# Altium schematic sheet MiniTVV4.SchDoc(MiniTVV4)
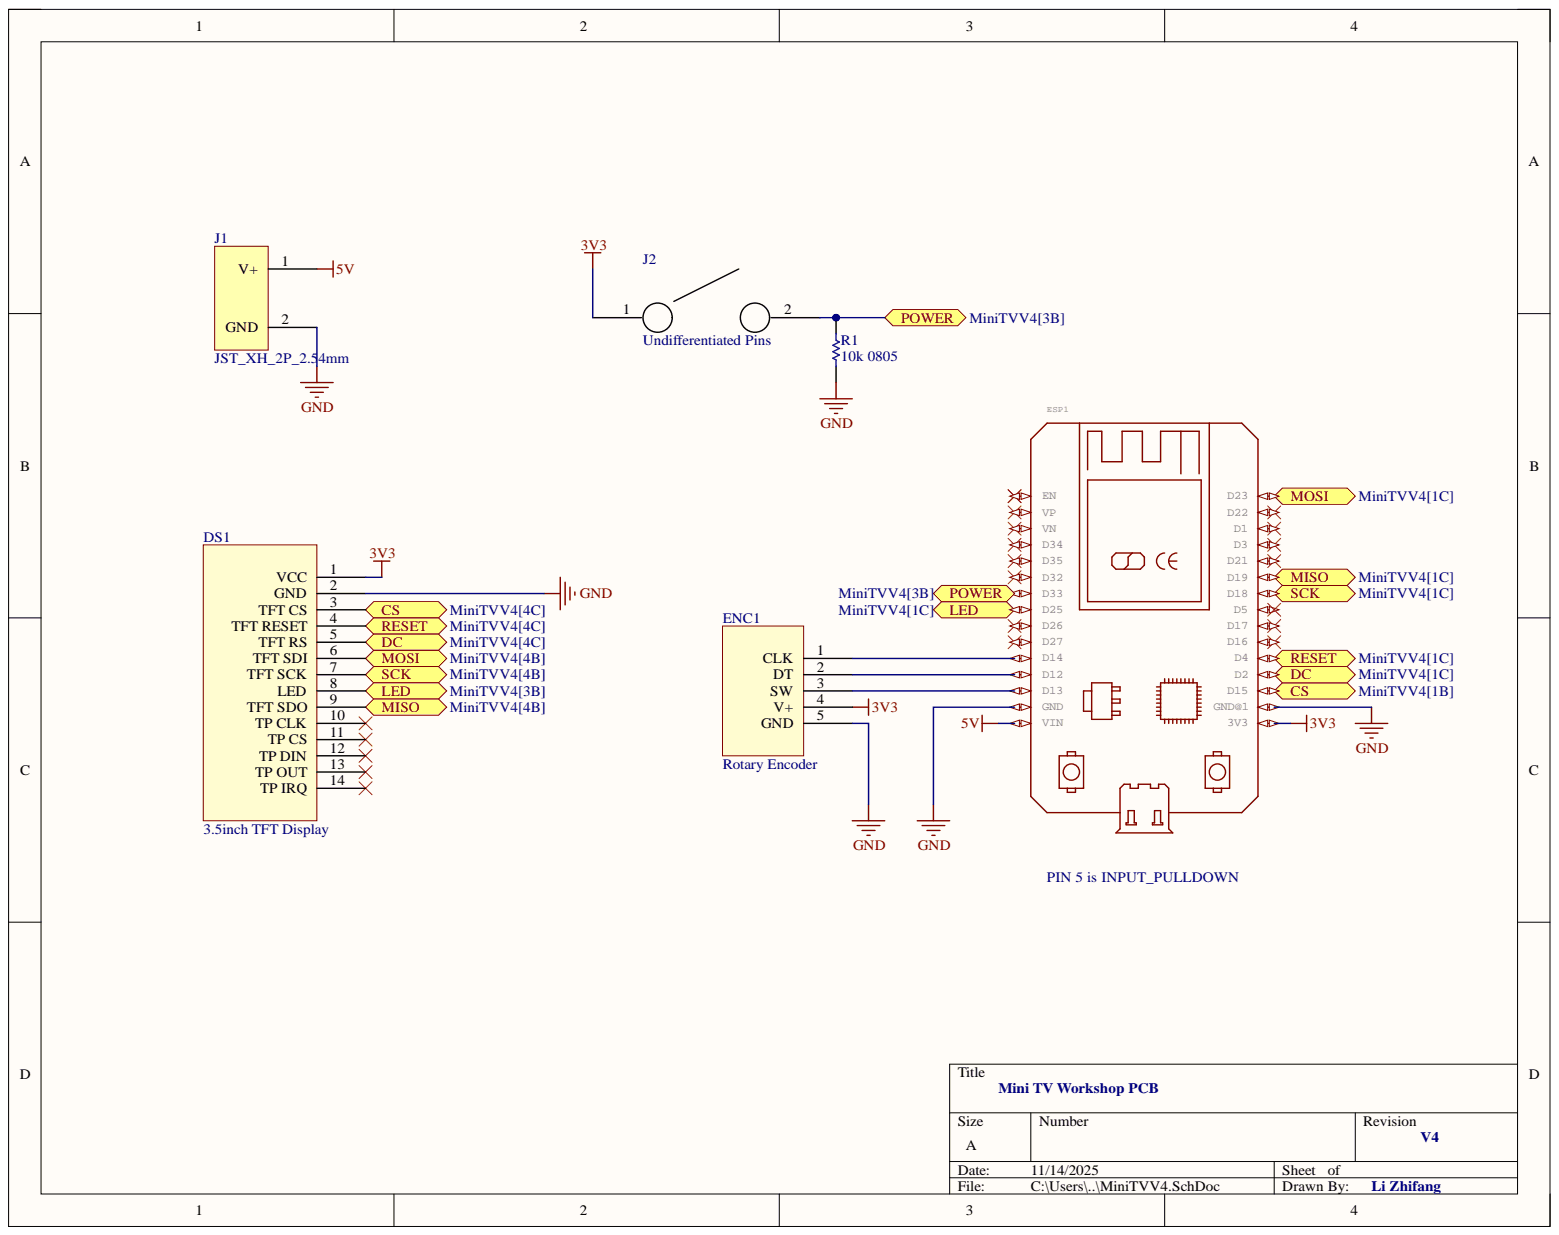
[diagram p0: MiniTVV4.SchDoc]

## Parts
DS1  3.5inch TFT Display  [3.5inch SPI Display]
ENC1  Rotary Encoder  [Rotary Encoder]
ESP1  https://github.com/shridattdudhat  [ESP32-DEVKITV1]  (value not drawn)
J1  JST_XH_2P_2.54mm  [JST_XH_2P_2.54mm]
J2  Undifferentiated Pins  [2-Pin 2.54mm]
R1  10k 0805  [RESC2013X60X35NL10T20]

## Ports
CS, DC, LED, MISO, MOSI, POWER, RESET, SCK

## Nets
3V3: DS1.1(VCC) ENC1.4(V+) ESP1.3V3 J2.1
5V: ESP1.VIN J1.1
GND: DS1.2(GND) ENC1.5(GND) ESP1.GND ESP1.GND@1 J1.2 R1.1
CS: DS1.3(CS) ESP1.D15 ; port CS
DC: DS1.5(RS) ESP1.D2 ; port DC
LED: DS1.8(LED) ESP1.D25 ; port LED
MISO: DS1.9(SDO) ESP1.D19 ; port MISO
MOSI: DS1.6(SDI) ESP1.D23 ; port MOSI
POWER: ESP1.D33 J2.2 R1.2 ; port POWER
RESET: DS1.4(RESET) ESP1.D4 ; port RESET
SCK: DS1.7(SCK) ESP1.D18 ; port SCK
NetENC1_1: ENC1.1(CLK) ESP1.D14
NetENC1_2: ENC1.2(DT) ESP1.D12
NetENC1_3: ENC1.3(SW) ESP1.D13

Not connected: DS1 10(TP_CLK) 11(TP_CS) 12(TP_DIN) 13(TP_OUT) 14(TP_IRQ); ESP1 D1 D3 D5 D16 D17 D21 D22 D26 D27 D32 D34 D35 EN VN VP

## Library data of the parts
ESP1 = ESP32DEVKITV1: GateName_1: G$1; Component Kind: Standard; DeviceSetName: ESP32DEVKITV1; Library Name: MiniTV.SchLib; LibraryName: ESP32-DEVKITV1; Package: ESP32-DEVKITV1; SymbolName_1: ESP32-DEVKITV1
J2 = SPST 2-Pin: Component Kind: Standard; Library Name: MiniTV.SchLib
R1 = CMP-2001-04523-1: Manufacturer: Yageo; Part Number: RC0805FR-104K7L; Component Kind: Standard; Library Name: Altium Content Vault
DS1 = 3.5inch TFT Display: Component Kind: Standard; Library Name: MiniTV.SchLib
ENC1 = Rotary Encoder: Component Kind: Standard; Library Name: MiniTV.SchLib
J1 = JST_XH_2P_2.54mm: Component Kind: Standard; Description: JST_XH_2P_2.54mm; Library Name: MiniTV.SchLib
